# Altium schematic sheet FTDI_USB.SchDoc(FTDI_USB)
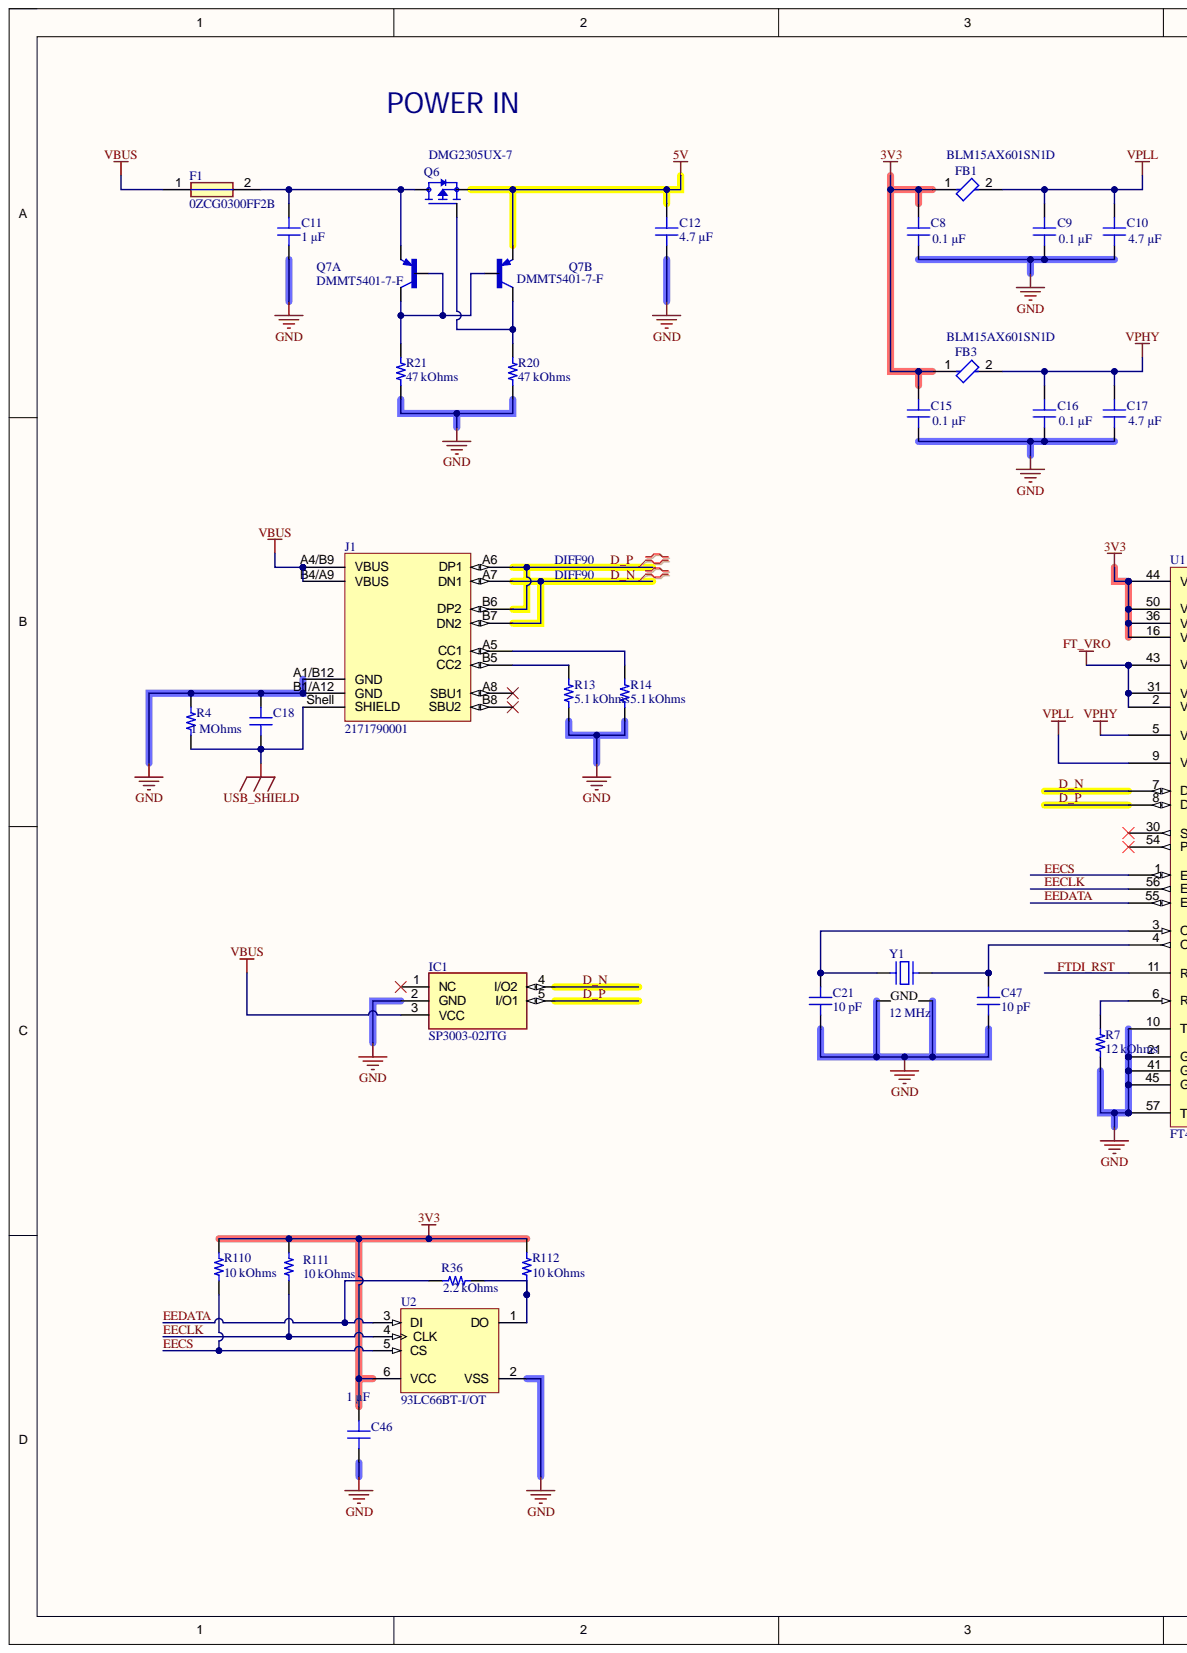
[diagram p0: FTDI_USB.SchDoc, left]
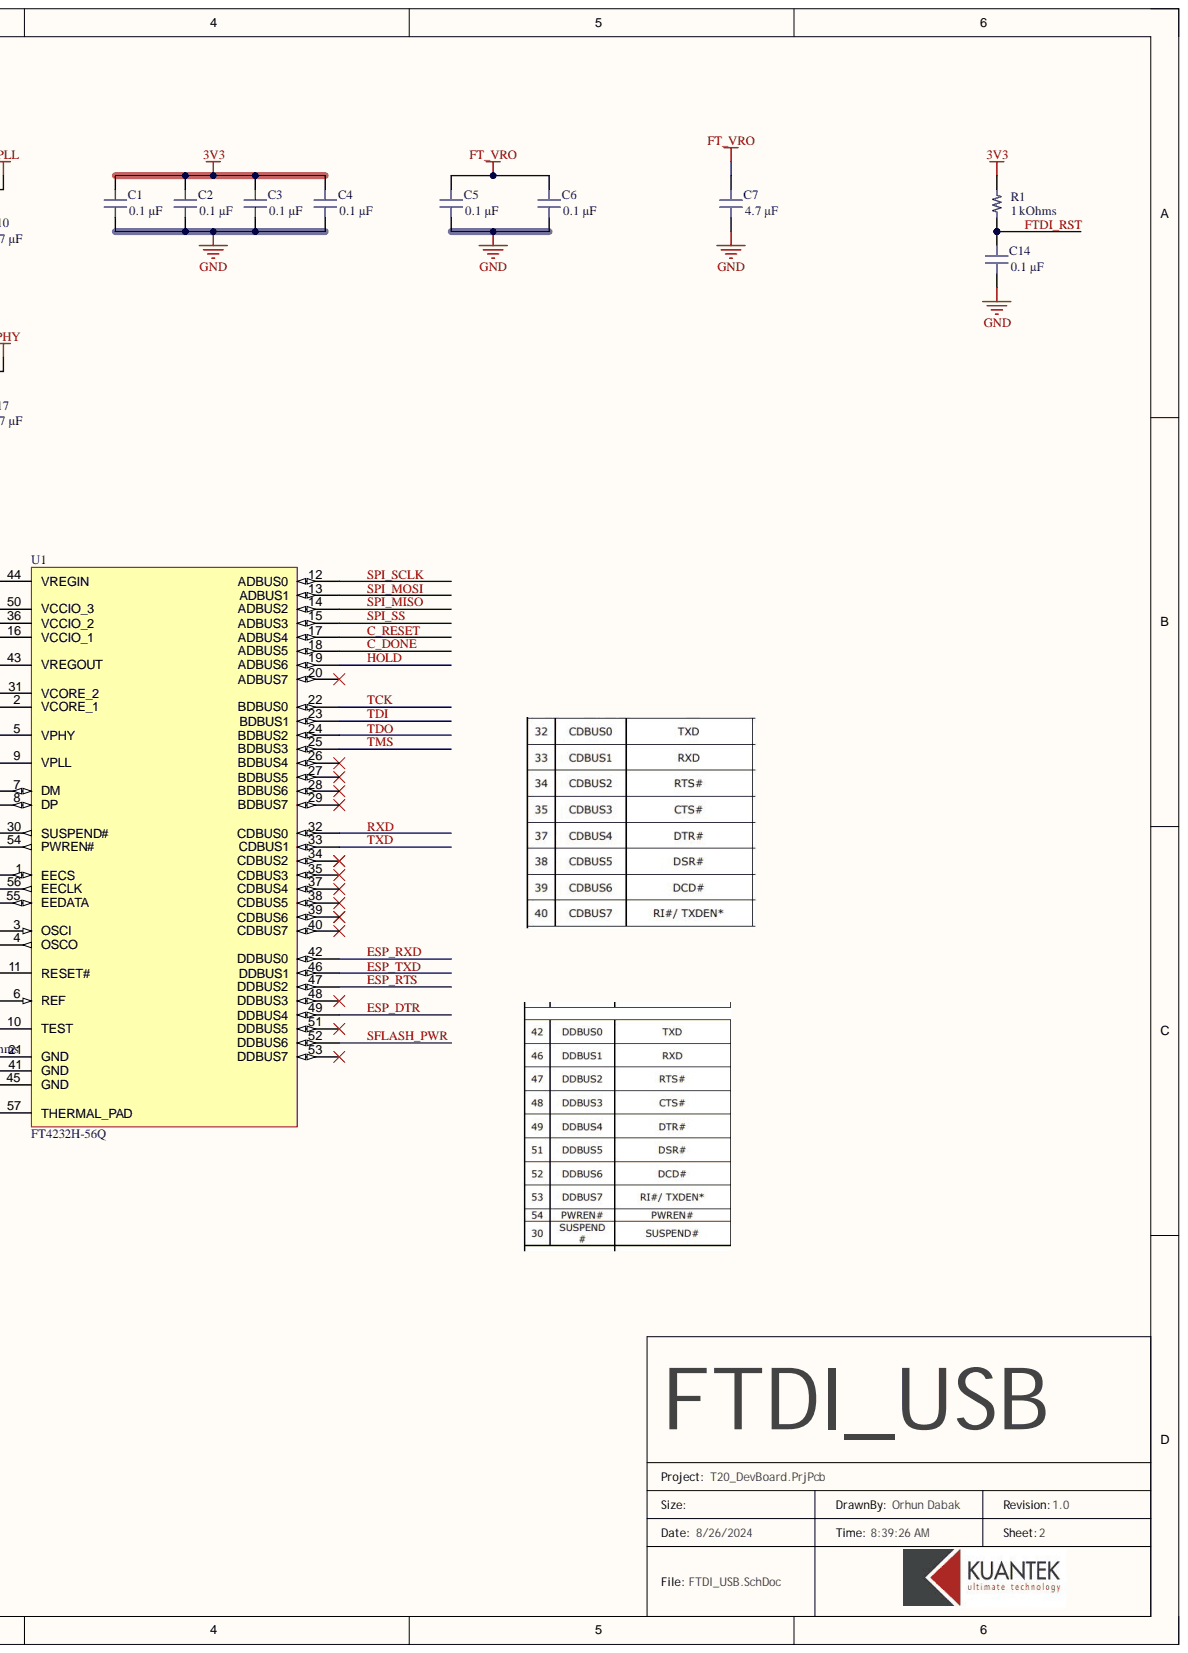
[diagram p1: FTDI_USB.SchDoc, right]

## Parts
C1  0.1 µF  [CAP 0402_1005]
C2  0.1 µF  [CAP 0402_1005]
C3  0.1 µF  [CAP 0402_1005]
C4  0.1 µF  [CAP 0402_1005]
C5  0.1 µF  [CAP 0402_1005]
C6  0.1 µF  [CAP 0402_1005]
C7  4.7 µF  [CAP 0402_1005]
C8  0.1 µF  [CAP 0402_1005]
C9  0.1 µF  [CAP 0402_1005]
C10  4.7 µF  [CAP 0402_1005]
C11  1 µF  [CAP 0805_2012]
C12  4.7 µF  [CAP 0805_2012]
C14  0.1 µF  [CAP 0402_1005]
C15  0.1 µF  [CAP 0402_1005]
C16  0.1 µF  [CAP 0402_1005]
C17  4.7 µF  [CAP 0402_1005]
C18  10000 pF  [CAP 0402_1005]  (value not drawn)
C21  10 pF  [CAP 0402_1005]
C46  1 µF  [CAP 0402_1005]
C47  10 pF  [CAP 0402_1005]
F1  0ZCG0300FF2B  [FUSC4632X155N]
FB1  BLM15AX601SN1D  [FP-BLM15-0_05-IPC_B]
FB3  BLM15AX601SN1D  [FP-BLM15-0_05-IPC_B]
IC1  SP3003-02JTG  [SOT65P220X110-5N]
J1  2171790001  [2171790001_MOL]
Q6  DMG2305UX-7  [SOT91P240X110-3N_DMG2305UX-7]
Q7  DMMT5401-7-F  [SOT95P285X140-6N]  (parts A,B)
R1  1 kOhms  [RES 0402_1005]
R4  1 MOhms  [RES 0402_1005]
R7  12 kOhms  [RES 0402_1005]
R13  5.1 kOhms  [RES 0402_1005]
R14  5.1 kOhms  [RES 0402_1005]
R20  47 kOhms  [RES 0402_1005]
R21  47 kOhms  [RES 0402_1005]
R36  2.2 kOhms  [RES 0402_1005]
R110  10 kOhms  [RES 0402_1005]
R111  10 kOhms  [RES 0402_1005]
R112  10 kOhms  [RES 0402_1005]
U1  FT4232H-56Q  [QFN50P800X800X100-57N-D]
U2  93LC66BT-I/OT  [SOT-23-OT6_N]
Y1  12 MHz  [NDK NX3225GA]

## Nets
3V3: C1.2 C2.2 C3.2 C4.2 C8.2 C15.2 C46.2 FB1.1 FB3.1 R1.2 R110.2 R111.2 R112.2 U1.16(VCCIO_1) U1.36(VCCIO_2) U1.44(VREGIN) U1.50(VCCIO_3) U2.6(VCC)
5V: C12.2 Q6.2 Q7.5
FT_VRO: C5.2 C6.2 C7.2 U1.2(VCORE_1) U1.31(VCORE_2) U1.43(VREGOUT)
GND: C1.1 C2.1 C3.1 C4.1 C5.1 C6.1 C7.1 C8.1 C9.1 C10.1 C11.1 C12.1 C14.1 C15.1 C16.1 C17.1 C18.2 C21.1 C46.1 C47.1 IC1.2(GND) J1.A1/B12(GND) J1.B1/A12(GND) R4.2 R7.1 R13.1 R14.1 R20.1 R21.1 U1.10(TEST) U1.21(GND) U1.41(GND) U1.45(GND) U1.57(THERMAL_PAD) U2.2(VSS) Y1.2 Y1.4
USB_SHIELD: C18.1 J1.Shell(SHIELD) R4.1
VBUS: F1.1 IC1.3(VCC) J1.A4/B9(VBUS) J1.B4/A9(VBUS)
VPHY: C16.2 C17.2 FB3.2 U1.5(VPHY)
VPLL: C9.2 C10.2 FB1.2 U1.9(VPLL)
C_DONE: U1.18(ADBUS5)
C_RESET: U1.17(ADBUS4)
D_N: IC1.4(I/O2) J1.A7(DN1) J1.B7(DN2) U1.7(DM)
D_P: IC1.5(I/O1) J1.A6(DP1) J1.B6(DP2) U1.8(DP)
EECLK: R111.1 U1.56(EECLK) U2.4(CLK)
EECS: R110.1 U1.1(EECS) U2.5(CS)
EEDATA: R36.1 U1.55(EEDATA) U2.3(DI)
ESP_DTR: U1.49(DDBUS4)
ESP_RTS: U1.47(DDBUS2)
ESP_RXD: U1.42(DDBUS0)
ESP_TXD: U1.46(DDBUS1)
FTDI_RST: C14.2 R1.1 U1.11(RESET#)
HOLD: U1.19(ADBUS6)
RXD: U1.32(CDBUS0)
SFLASH_PWR: U1.52(DDBUS6)
SPI_MISO: U1.14(ADBUS2)
SPI_MOSI: U1.13(ADBUS1)
SPI_SCLK: U1.12(ADBUS0)
SPI_SS: U1.15(ADBUS3)
TCK: U1.22(BDBUS0)
TDI: U1.23(BDBUS1)
TDO: U1.24(BDBUS2)
TMS: U1.25(BDBUS3)
TXD: U1.33(CDBUS1)
NetC11_2: C11.2 F1.2 Q6.3 Q7.6
NetC21_2: C21.2 U1.3(OSCI) Y1.1
NetC47_2: C47.2 U1.4(OSCO) Y1.3
NetJ1_A5: J1.A5(CC1) R14.2
NetJ1_B5: J1.B5(CC2) R13.2
NetQ6_1: Q6.1 Q7.4 R20.2
NetQ7_1: Q7.1 Q7.2 Q7.3 R21.2
NetR7_2: R7.2 U1.6(REF)
NetR36_2: R36.2 R112.1 U2.1(DO)

Not connected: IC1 1(NC); J1 A8(SBU1) B8(SBU2); U1 20(ADBUS7) 26(BDBUS4) 27(BDBUS5) 28(BDBUS6) 29(BDBUS7) 30(SUSPEND#) 34(CDBUS2) 35(CDBUS3) 37(CDBUS4) 38(CDBUS5) 39(CDBUS6) 40(CDBUS7) 48(DDBUS3) 51(DDBUS5) 53(DDBUS7) 54(PWREN#)

## Library data of the parts
C1-C6 C8 C9 C14-C16 = CC0402KRX7R6BB104: CAP CER 0.1UF 10V X7R 0402; manufacturer: Yageo; Database Table Name: Capacitors - Ceramic - 0402; Tolerance: ±10%; Applications: General Purpose; Capacitance: 0.1 µF; Component Kind: Standard; componentlink1description: Datasheet; componentlink1url: https://www.yageo.com/upload/media/product/productsearch/datasheet/mlcc/UPY-GPHC_X7R_6.3V-to-50V_18.pdf; componentlink2description: DigiKey Link; componentlink2url: https://www.digikey.com/en/products/detail/yageo/CC0402KRX7R6BB104/2103083; Device Package: 0402 (1005 Metric); lastupdated: 19/09/2022 12:57:28; Library Name: Celestial Altium Library - altium_library.DbLib; Lifecycle Status: Active; Minimum Order: 1; Mounting Type: Surface Mount, MLCC; Operating Temperature: -55°C ~ 125°C; Package / Case: 0402 (1005 Metric); packaging: CutTape; Part Number: CC0402KRX7R6BB104; Part Status: Active; partid: 3877; price: 0.01; series: CC; Size / Dimension: 0.039" L x 0.020" W (1.00mm x 0.50mm); Supplier 1: DigiKey; Supplier Part Number 1: 311-1345-1-ND; Temperature Coefficient: X7R; Thickness (Max): 0.022" (0.55mm); Value: 0.1 µF; Voltage - Rated: 10V
C7 C10 C17 = CL05A475MP5NRNC: CAP CER 4.7UF 10V X5R 0402; manufacturer: Samsung Electro-Mechanics; Database Table Name: Capacitors - Ceramic - 0402; Tolerance: ±20%; Applications: General Purpose; Capacitance: 4.7 µF; Component Kind: Standard; componentlink1description: Datasheet; componentlink1url: http://www.samsungsem.com/kr/support/product-search/mlcc/CL05A475MP5NRNC.jsp; componentlink2description: DigiKey Link; componentlink2url: https://www.digikey.com/en/products/detail/samsung-electro-mechanics/CL05A475MP5NRNC/3887140; Device Package: 0402 (1005 Metric); lastupdated: 19/09/2022 12:57:17; Library Name: Celestial Altium Library - altium_library.DbLib; Lifecycle Status: Active; Minimum Order: 1; Mounting Type: Surface Mount, MLCC; Operating Temperature: -55°C ~ 85°C; Package / Case: 0402 (1005 Metric); packaging: TapeAndReel; Part Number: CL05A475MP5NRNC; Part Status: Active; partid: 7650; price: 0.01; series: CL; Size / Dimension: 0.039" L x 0.020" W (1.00mm x 0.50mm); Supplier 1: DigiKey; Supplier Part Number 1: 1276-1482-1-ND; Temperature Coefficient: X5R; Thickness (Max): 0.022" (0.55mm); Value: 4.7 µF; Voltage - Rated: 10V
C11 = CL21B105KAFNNNE: CAP CER 1UF 25V X7R 0805; manufacturer: Samsung Electro-Mechanics; Database Table Name: Capacitors - Ceramic - 0805; Tolerance: ±10%; Applications: General Purpose; Capacitance: 1 µF; Component Kind: Standard; componentlink1description: Datasheet; componentlink1url: http://www.samsungsem.com/kr/support/product-search/mlcc/CL21B105KAFNNNE.jsp; componentlink2description: DigiKey Link; componentlink2url: https://www.digikey.com/en/products/detail/samsung-electro-mechanics/CL21B105KAFNNNE/3886724; Device Package: 0805 (2012 Metric); lastupdated: 11/30/2023 08:15:33 PM; Library Name: Celestial Altium Library - altium_library.DbLib; Lifecycle Status: Active; Minimum Order: 1; Mounting Type: Surface Mount, MLCC; Operating Temperature: -55°C ~ 125°C; Package / Case: 0805 (2012 Metric); packaging: TapeAndReel; Part Number: CL21B105KAFNNNE; Part Status: Active; partid: 6211; price: 0.01; series: CL; Size / Dimension: 0.079" L x 0.049" W (2.00mm x 1.25mm); Supplier 1: DigiKey; Supplier Part Number 1: 1276-1066-1-ND; Temperature Coefficient: X7R; Thickness (Max): 0.053" (1.35mm); Value: 1 µF; Voltage - Rated: 25V
C12 = TMK212BBJ475MD-T: CAP CER 4.7UF 25V X5R 0805; manufacturer: Taiyo Yuden; Database Table Name: Capacitors - Ceramic - 0805; Tolerance: ±20%; Applications: SMPS Filtering; Capacitance: 4.7 µF; Component Kind: Standard; componentlink1description: Datasheet; componentlink1url: https://www.yuden.co.jp/productdata/catalog/mlcc01_e.pdf; componentlink2description: DigiKey Link; componentlink2url: https://www.digikey.com/en/products/detail/taiyo-yuden/TMK212BBJ475MD-T/6563533; Device Package: 0805 (2012 Metric); lastupdated: 11/30/2023 08:15:39 PM; Library Name: Celestial Altium Library - altium_library.DbLib; Lifecycle Status: Active; Minimum Order: 1; Mounting Type: Surface Mount, MLCC; Operating Temperature: -55°C ~ 85°C; Package / Case: 0805 (2012 Metric); packaging: TapeAndReel; Part Number: TMK212BBJ475MD-T; Part Status: Active; partid: 3784; price: 0.03; series: M; Size / Dimension: 0.079" L x 0.049" W (2.00mm x 1.25mm); Supplier 1: DigiKey; Supplier Part Number 1: 587-4940-1-ND; Temperature Coefficient: X5R; Thickness (Max): 0.037" (0.95mm); Value: 4.7 µF; Voltage - Rated: 25V
C18 = CC0402KRX7R0BB103: CAP CER 10000PF 100V X7R 0402; manufacturer: Yageo; Database Table Name: Capacitors - Ceramic - 0402; Tolerance: ±10%; Applications: General Purpose; Capacitance: 10000 pF; Component Kind: Standard; componentlink1description: Datasheet; componentlink1url: https://www.yageo.com/upload/media/product/productsearch/datasheet/mlcc/UPY-NP0X7R_MV_100-to-630V_24.pdf; componentlink2description: DigiKey Link; componentlink2url: https://www.digikey.com/en/products/detail/yageo/CC0402KRX7R0BB103/11489823; Device Package: 0402 (1005 Metric); lastupdated: 19/09/2022 12:56:51; Library Name: Celestial Altium Library - altium_library.DbLib; Lifecycle Status: Active; Minimum Order: 1; Mounting Type: Surface Mount, MLCC; Operating Temperature: -55°C ~ 125°C; Package / Case: 0402 (1005 Metric); packaging: TapeAndReel; Part Number: CC0402KRX7R0BB103; Part Status: Active; partid: 33421; price: 0.01; series: CC; Size / Dimension: 0.039" L x 0.020" W (1.00mm x 0.50mm); Supplier 1: DigiKey; Supplier Part Number 1: 13-CC0402KRX7R0BB103CT-ND; Temperature Coefficient: X7R; Thickness (Max): 0.022" (0.55mm); Value: 10000 pF; Voltage - Rated: 100V
C21 C47 = 885012005055: CAP CER 10PF 50V C0G/NP0 0402; manufacturer: Würth Elektronik; Database Table Name: Capacitors - Ceramic - 0402; Temperature Coefficient: C0G, NP0; Tolerance: ±5%; Applications: General Purpose; Capacitance: 10 pF; Component Kind: Standard; componentlink1description: Datasheet; componentlink1url: https://katalog.we-online.de/pbs/datasheet/885012005055.pdf; componentlink2description: DigiKey Link; componentlink2url: https://www.digikey.com/en/products/detail/würth-elektronik/885012005055/5453324; Device Package: 0402 (1005 Metric); lastupdated: 11/29/2023 08:04:44 PM; Library Name: Celestial Altium Library - altium_library.DbLib; Lifecycle Status: Active; Minimum Order: 1; Mounting Type: Surface Mount, MLCC; Operating Temperature: -55°C ~ 125°C; Package / Case: 0402 (1005 Metric); packaging: TapeAndReel; Part Number: 885012005055; Part Status: Active; partid: 1896; price: 0.01; series: WCAP-CSGP; Size / Dimension: 0.039" L x 0.020" W (1.00mm x 0.50mm); Supplier 1: DigiKey; Supplier Part Number 1: 732-7469-1-ND; Thickness (Max): 0.022" (0.55mm); Value: 10 pF; Voltage - Rated: 50V
C46 = GRM155R70J105KA12J: CAP CER 1UF 6.3V X7R 0402; manufacturer: Murata Electronics; Database Table Name: Capacitors - Ceramic - 0402; Tolerance: ±10%; Applications: General Purpose; Capacitance: 1 µF; Component Kind: Standard; componentlink1description: Datasheet; componentlink1url: https://search.murata.co.jp/Ceramy/image/img/A01X/G101/ENG/GRM155R70J105KA12-01.pdf; componentlink2description: DigiKey Link; componentlink2url: https://www.digikey.com/en/products/detail/murata-electronics/GRM155R70J105KA12J/4905166; Device Package: 0402 (1005 Metric); lastupdated: 19/09/2022 12:57:31; Library Name: Celestial Altium Library - altium_library.DbLib; Lifecycle Status: Active; Minimum Order: 1; Mounting Type: Surface Mount, MLCC; Operating Temperature: -55°C ~ 125°C; Package / Case: 0402 (1005 Metric); packaging: TapeAndReel; Part Number: GRM155R70J105KA12J; Part Status: Active; partid: 2890; price: 0.01; series: GRM; Size / Dimension: 0.039" L x 0.020" W (1.00mm x 0.50mm); Supplier 1: DigiKey; Supplier Part Number 1: 490-13339-1-ND; Temperature Coefficient: X7R; Thickness (Max): 0.022" (0.55mm); Value: 1 µF; Voltage - Rated: 6.3V
F1 = 0ZCG0300FF2B: Fuse; Manufacturer_Name: BelFuse; Arrow Part Number: 0ZCG0300FF2B; Arrow Price/Stock: https://www.arrow.com/en/products/0zcg0300ff2b/bel-group; Component Kind: Standard; Datasheet Link: https://datasheet.datasheetarchive.com/originals/distributors/Datasheets_SAMA/44944b3a27f8483a7253631e04029a1a.pdf; Height: 1.55mm; Manufacturer_Part_Number: 0ZCG0300FF2B; Mouser Part Number: 530-0ZCG0300FF2B; Mouser Price/Stock: https://www.mouser.co.uk/ProductDetail/Bel-Fuse/0ZCG0300FF2B?qs=SRYZG9HaIQ05yXZrpiJOLQ%3D%3D; Library Name: Kuantek_SO-DIMM.SchLib
FB1 FB3 = CMP-0686-00246-4: Chip Ferrite Bead, 0402, 600Ω @ 100MHz, 0.34Ω, 25%, 500mA; DC Resistance (DCR): 340mR; Impedance: 600R; Material: Steel; Number of Circuits: 1; Case Code (Imperial): 0402; Case Code (Metric): 1005; Case/Package: 0402; Component Kind: Standard; Core Material: Ferrite; Current Rating: 500mA; DC Resistance-Max: 340mR; Depth: 0.5mm; Height: 0.5mm; Lead Free: Lead Free; Length: 1mm; Library Name: Altium Content Vault; Max Current Rating: 500mA; Max DC Current: 500mA; Max Operating Temperature: 125°C; Min Operating Temperature: -55°C; Number of Lines: 1; Number of Pins: 2; Package Quantity: 1; Packaging: Tape and Reel; REACH SVHC: No SVHC; RoHS Compliant: Yes; Series: BLM15AX_SN; Termination: SMD/SMT; Test Frequency: 100MHz; Tolerance: 25%; Width: 0.5mm
IC1 = SP3003-02JTG: Integrated Circuit; Manufacturer_Name: LITTELFUSE; Arrow Part Number: SP3003-02JTG; Arrow Price/Stock: https://www.arrow.com/en/products/sp3003-02jtg/littelfuse?region=nac; Component Kind: Standard; Datasheet Link: https://datasheet.datasheetarchive.com/originals/distributors/Datasheets-DGA26/1840396.pdf; Height: 1.1mm; Manufacturer_Part_Number: SP3003-02JTG; Mouser Part Number: 576-SP3003-02JTG; Mouser Price/Stock: https://www.mouser.co.uk/ProductDetail/Littelfuse/SP3003-02JTG?qs=je4hkJKt%2FJ1VE%2FhJSW73jg%3D%3D
J1 = 2171790001: No Description Available; Mfr_Name: Molex Connector Corporation; Component Kind: Standard; Digi-Key_Part_Number_1: 900-2171790001TR-ND; Digi-Key_Part_Number_2: 900-2171790001CT-ND; Digi-Key_Part_Number_3: 900-2171790001DKR-ND; Library Name: 2171790001.SchLib; Manufacturer_Part_Number: 2171790001; RefDes: RefDes; Type: DEV; Copyright: Copyright (C) 2023 Ultra Librarian. All rights reserved.
Q6 = DMG2305UX-7: P-Channel 20V 4.2A _Ta_ 1.4W _Ta_ Surface Mount SOT-23; MF: Power Analog Micro; Availability: Unavailable; Component Kind: Standard; MP: DMG2305UX-7; Package: TO-236-3 Power Analog Micro; Price: None; SnapEDA_Link: https://www.snapeda.com/parts/DMG2305UX-7/Power%20Analog%20Micro/view-part/174848/?ref=snap; Library Name: Kuantek_SO-DIMM.SchLib
Q7 = DMMT5401-7-F: Bipolar _BJT_ Transistor Array 2 PNP _Dual_ Matched Pair 150V 200mA 300MHz 300mW Surface Mount SOT-26; MF: Diodes Inc.; Availability: Unavailable; Component Kind: Standard; MP: DMMT5401-7-F; Package: SOT-23 Diodes Inc.; Price: None; SnapEDA_Link: https://www.snapeda.com/parts/DMMT5401-7-F/Diodes%20Inc./view-part/106255/?ref=snap; Library Name: Kuantek_SO-DIMM.SchLib
R1 = AC0402FR-071KL: RES SMD 1K OHM 1% 1/16W 0402; Manufacturer: Yageo; Database Table Name: Resistors - Surface Mount - 0402; Power (Watts): 0.063W, 1/16W; Temperature Coefficient: ±100ppm/°C; Component Kind: Standard; ComponentLink1Description: Datasheet; ComponentLink1URL: https://www.yageo.com/upload/media/product/productsearch/datasheet/rchip/PYu-AC_51_RoHS_L_7.pdf; ComponentLink2Description: DigiKey Link; ComponentLink2URL: https://www.digikey.com/en/products/detail/yageo/AC0402FR-071KL/2827801; Composition: Thick Film; Device Package: 0402 (1005 Metric); Features: Automotive AEC-Q200, Moisture Resistant; Height - Seated (Max): 0.015" (0.37mm); LastUpdated: 19/09/2022 13:14:31; Library Name: Celestial Altium Library - altium_library.DbLib; Lifecycle Status: Active; Minimum Order: 1; Number of Terminations: 2; Operating Temperature: -55°C ~ 155°C; Package / Case: 0402 (1005 Metric); Packaging: CutTape; Part Number: AC0402FR-071KL; Part Status: Active; PartId: 12037; Price: 0.01; Ratings: AEC-Q200; Resistance: 1 kOhms; Series: AC; Size / Dimension: 0.039" L x 0.020" W (1.00mm x 0.50mm); Supplier 1: DigiKey; Supplier Device Package: 0402; Supplier Part Number 1: 311-1KLBCT-ND; Tolerance: ±1%; Value: 1 kOhms
R4 = AC0402FR-071ML: RES SMD 1M OHM 1% 1/16W 0402; Manufacturer: Yageo; Database Table Name: Resistors - Surface Mount - 0402; Power (Watts): 0.063W, 1/16W; Temperature Coefficient: ±100ppm/°C; Component Kind: Standard; ComponentLink1Description: Datasheet; ComponentLink1URL: https://www.yageo.com/upload/media/product/productsearch/datasheet/rchip/PYu-AC_51_RoHS_L_7.pdf; ComponentLink2Description: DigiKey Link; ComponentLink2URL: https://www.digikey.com/en/products/detail/yageo/AC0402FR-071ML/5895164; Composition: Thick Film; Device Package: 0402 (1005 Metric); Features: Automotive AEC-Q200, Moisture Resistant; Height - Seated (Max): 0.015" (0.37mm); LastUpdated: 19/09/2022 13:14:31; Library Name: Celestial Altium Library - altium_library.DbLib; Lifecycle Status: Active; Minimum Order: 1; Number of Terminations: 2; Operating Temperature: -55°C ~ 155°C; Package / Case: 0402 (1005 Metric); Packaging: CutTape; Part Number: AC0402FR-071ML; Part Status: Active; PartId: 12043; Price: 0.01; Ratings: AEC-Q200; Resistance: 1 MOhms; Series: AC; Size / Dimension: 0.039" L x 0.020" W (1.00mm x 0.50mm); Supplier 1: DigiKey; Supplier Device Package: 0402; Supplier Part Number 1: YAG3450CT-ND; Tolerance: ±1%; Value: 1 MOhms
R7 = CPF0402B12KE1: RES SMD 12K OHM 0.1% 1/16W 0402; Manufacturer: TE Connectivity Passive Product; Database Table Name: Resistors - Surface Mount - 0402; Power (Watts): 0.063W, 1/16W; Temperature Coefficient: ±25ppm/°C; Component Kind: Standard; ComponentLink1Description: Datasheet; ComponentLink1URL: https://www.te.com/commerce/DocumentDelivery/DDEController?Action=srchrtrv&DocNm=1773200&DocType=DS&DocLang=English; ComponentLink2Description: DigiKey Link; ComponentLink2URL: https://www.digikey.com/en/products/detail/te-connectivity-passive-product/CPF0402B12KE1/2381830; Composition: Thin Film; Device Package: 0402 (1005 Metric); Height - Seated (Max): 0.014" (0.35mm); LastUpdated: 1/2/2023 08:21:48 PM; Library Name: Celestial Altium Library - altium_library.DbLib; Lifecycle Status: Active; Minimum Order: 1; Number of Terminations: 2; Operating Temperature: -55°C ~ 155°C; Package / Case: 0402 (1005 Metric); Packaging: TapeAndReel; Part Number: CPF0402B12KE1; Part Status: Active; PartId: 3318; Price: 0.13; Resistance: 12 kOhms; Series: CPF, Neohm; Size / Dimension: 0.039" L x 0.020" W (1.00mm x 0.50mm); Supplier 1: DigiKey; Supplier Device Package: 0402; Supplier Part Number 1: A102603CT-ND; Tolerance: ±0.1%; Value: 12 kOhms
R13 R14 = AC0402JR-075K1L: RES SMD 5.1K OHM 5% 1/16W 0402; Manufacturer: Yageo; Database Table Name: Resistors - Surface Mount - 0402; Power (Watts): 0.063W, 1/16W; Temperature Coefficient: ±100ppm/°C; Component Kind: Standard; ComponentLink1Description: Datasheet; ComponentLink1URL: https://www.yageo.com/upload/media/product/productsearch/datasheet/rchip/PYu-AC_51_RoHS_L_7.pdf; ComponentLink2Description: DigiKey Link; ComponentLink2URL: https://www.digikey.com/en/products/detail/yageo/AC0402JR-075K1L/5895695; Composition: Thick Film; Device Package: 0402 (1005 Metric); Features: Automotive AEC-Q200, Moisture Resistant; Height - Seated (Max): 0.015" (0.37mm); LastUpdated: 1/2/2023 08:21:43 PM; Library Name: Celestial Altium Library - altium_library.DbLib; Lifecycle Status: Active; Minimum Order: 1; Number of Terminations: 2; Operating Temperature: -55°C ~ 155°C; Package / Case: 0402 (1005 Metric); Packaging: CutTape; Part Number: AC0402JR-075K1L; Part Status: Active; PartId: 4690; Price: 0.01; Ratings: AEC-Q200; Resistance: 5.1 kOhms; Series: AC; Size / Dimension: 0.039" L x 0.020" W (1.00mm x 0.50mm); Supplier 1: DigiKey; Supplier Device Package: 0402; Supplier Part Number 1: YAG3554CT-ND; Tolerance: ±5%; Value: 5.1 kOhms
R20 R21 = RMCF0402FT47K0: RES 47K OHM 1% 1/16W 0402; Manufacturer: Stackpole Electronics Inc; Database Table Name: Resistors - Surface Mount - 0402; Power (Watts): 0.063W, 1/16W; Temperature Coefficient: ±100ppm/°C; Component Kind: Standard; ComponentLink1Description: Datasheet; ComponentLink1URL: https://www.seielect.com/catalog/sei-rmcf_rmcp.pdf; ComponentLink2Description: DigiKey Link; ComponentLink2URL: https://www.digikey.com/en/products/detail/stackpole-electronics-inc/RMCF0402FT47K0/1714749; Composition: Thick Film; Device Package: 0402 (1005 Metric); Features: Automotive AEC-Q200; Height - Seated (Max): 0.016" (0.40mm); LastUpdated: 1/2/2023 08:21:41 PM; Library Name: Celestial Altium Library - altium_library.DbLib; Lifecycle Status: Active; Minimum Order: 1; Number of Terminations: 2; Operating Temperature: -55°C ~ 155°C; Package / Case: 0402 (1005 Metric); Packaging: CutTape; Part Number: RMCF0402FT47K0; Part Status: Active; PartId: 4957; Price: 0.01; Ratings: AEC-Q200; Resistance: 47 kOhms; Series: RMCF; Size / Dimension: 0.039" L x 0.020" W (1.00mm x 0.50mm); Supplier 1: DigiKey; Supplier Device Package: 0402; Supplier Part Number 1: RMCF0402FT47K0CT-ND; Tolerance: ±1%; Value: 47 kOhms
R36 = TNPW04022K20BYEP: RES 2.2K OHM 0.1% 1/10W 0402; Manufacturer: Vishay Dale; Database Table Name: Resistors - Surface Mount - 0402; Power (Watts): 0.1W, 1/10W; Temperature Coefficient: ±10ppm/°C; Component Kind: Standard; ComponentLink1Description: Datasheet; ComponentLink1URL: http://www.vishay.com/docs/28758/tnpw_e3.pdf; ComponentLink2Description: DigiKey Link; ComponentLink2URL: https://www.digikey.com/en/products/detail/vishay-dale/TNPW04022K20BYEP/8636618; Composition: Thin Film; Device Package: 0402 (1005 Metric); Features: Anti-Sulfur, Automotive AEC-Q200, Moisture Resistant; Height - Seated (Max): 0.016" (0.40mm); LastUpdated: 1/2/2023 08:21:49 PM; Library Name: Celestial Altium Library - altium_library.DbLib; Lifecycle Status: Active; Minimum Order: 1; Number of Terminations: 2; Operating Temperature: -55°C ~ 155°C; Package / Case: 0402 (1005 Metric); Packaging: TapeAndReel; Part Number: TNPW04022K20BYEP; Part Status: Active; PartId: 3077; Price: 0.28; Ratings: AEC-Q200; Resistance: 2.2 kOhms; Series: TNPW e3; Size / Dimension: 0.039" L x 0.020" W (1.00mm x 0.50mm); Supplier 1: DigiKey; Supplier Device Package: 0402; Supplier Part Number 1: 541-9805-1-ND; Tolerance: ±0.1%; Value: 2.2 kOhms
R110-R112 = RC0402JR-0710KL: RES 10K OHM 5% 1/16W 0402; Manufacturer: Yageo; Database Table Name: Resistors - Surface Mount - 0402; Power (Watts): 0.063W, 1/16W; Temperature Coefficient: ±100ppm/°C; Component Kind: Standard; ComponentLink1Description: Datasheet; ComponentLink1URL: https://www.yageo.com/upload/media/product/productsearch/datasheet/rchip/PYu-RC_Group_51_RoHS_L_10.pdf; ComponentLink2Description: DigiKey Link; ComponentLink2URL: https://www.digikey.com/en/products/detail/yageo/RC0402JR-0710KL/726418; Composition: Thick Film; Device Package: 0402 (1005 Metric); Features: Moisture Resistant; Height - Seated (Max): 0.016" (0.40mm); LastUpdated: 4/7/2023 07:22:44 PM; Library Name: Celestial Altium Library - altium_library.DbLib; Lifecycle Status: Active; Minimum Order: 1; Number of Terminations: 2; Operating Temperature: -55°C ~ 155°C; Package / Case: 0402 (1005 Metric); Packaging: CutTape; Part Number: RC0402JR-0710KL; Part Status: Active; PartId: 20526; Price: 0.01; Resistance: 10 kOhms; Series: RC_L; Size / Dimension: 0.039" L x 0.020" W (1.00mm x 0.50mm); Supplier 1: DigiKey; Supplier Device Package: 0402; Supplier Part Number 1: 311-10KJRCT-ND; Tolerance: ±5%; Value: 10 kOhms
U1 = FT4232H-56Q: USB Bridge, USB to UART,FIFO USB 2.0 FIFO Interface 56-VQFN (8x8); Manufacturer: FTDI, Future Technology Devices International Ltd; Manufacturer Product Number: FT4232H-56Q-REEL; Standards: USB 2.0; Voltage - Supply: 3.3V; Component Kind: Standard; ComponentLink1Description: Datasheet; ComponentLink1URL: https://www.ftdichip.com/Support/Documents/DataSheets/ICs/DS_FT4232H.pdf; ComponentLink2Description: Digi-Key Link; ComponentLink2URL: https://www.digikey.com/en/products/detail/ftdi-future-technology-devices-international-ltd/FT4232H-56Q-REEL/5994692; Current - Supply: -; Function: Bridge, USB to UART,FIFO; Interface: FIFO; Library Name: T8_devkit_PCB.SchLib; Operating Temperature: -40°C ~ 85°C; Package / Case: 56-VFQFN Exposed Pad; Protocol: USB; Series: -; Supplier 1: Digi-Key; Supplier 2: Digi-Key; Supplier 3: RSComponents; Supplier Device Package: 56-VQFN (8x8); Supplier Part Number 1: 768-1378-1-ND; Supplier Part Number 2: 768-1378-6-ND; Supplier Part Number 3: 1115836
U2 = CMP-019-00051-3: Microwire Serial EEPROM Family, 2.5Vto 5.5V' 2 MHz, -40°Cto 125°C, SOT-23-6, RoHS; Component Kind: Standard; Library Name: Kocaeli University
Y1 = NX3225GA-12MHZ-STD-CRG-2: CRYSTAL 12.0000MHZ 8PF SMD; Manufacturer: NDK America, Inc.; Database Table Name: Oscillators - Crystals; ESR (Equivalent Series Resistance): 100 Ohms; Frequency Stability: ±30ppm; Frequency Tolerance: ±20ppm; Operating Mode: Fundamental; Component Kind: Standard; ComponentLink1Description: Datasheet; ComponentLink1URL: http://www.ndk.com/images/products/catalog/c_NX3225GA-STD-CRG-2_e.pdf; ComponentLink2Description: DigiKey Link; ComponentLink2URL: https://www.digikey.com/en/products/detail/ndk-america-inc/NX3225GA-12MHZ-STD-CRG-2/3125632; Frequency: 12 MHz; Height - Seated (Max): 0.035" (0.90mm); LastUpdated: 19/09/2022 13:51:54; Library Name: Celestial Altium Library - altium_library.DbLib; Lifecycle Status: Active; Load Capacitance: 8pF; Minimum Order: 1; Mounting Type: Surface Mount; Operating Temperature: -40°C ~ 85°C; Package / Case: 4-SMD, No Lead; Packaging: TapeAndReel; Part Number: NX3225GA-12MHZ-STD-CRG-2; Part Status: Active; PartId: 551; Price: 0.33; Series: NX3225GA; Size / Dimension: 0.126" L x 0.098" W (3.20mm x 2.50mm); Supplier 1: DigiKey; Supplier Part Number 1: 644-1173-1-ND; Type: MHz Crystal; Value: 12 MHz
